# Altium schematic sheet REX 9628 Extern Kernel 8.SchDoc("REX 9628 Extern Kernel 8")
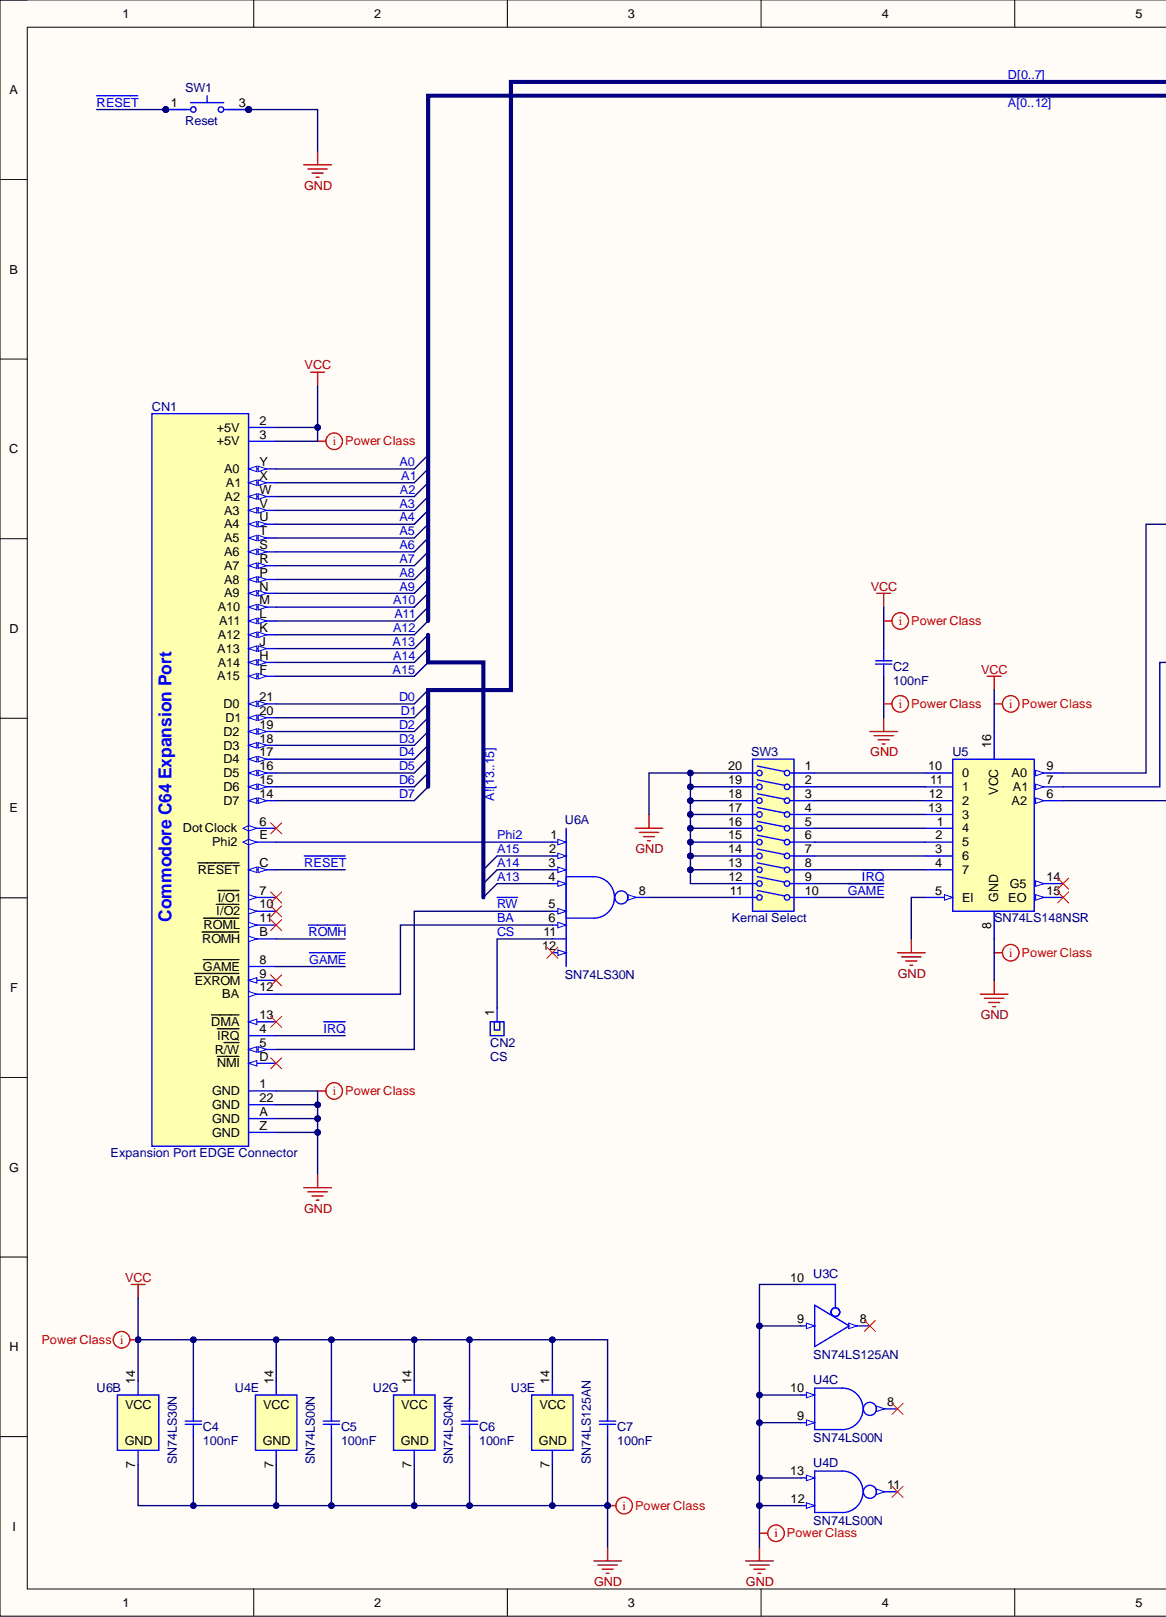
[diagram p0: REX 9628 Extern Kernel 8.SchDoc, left]
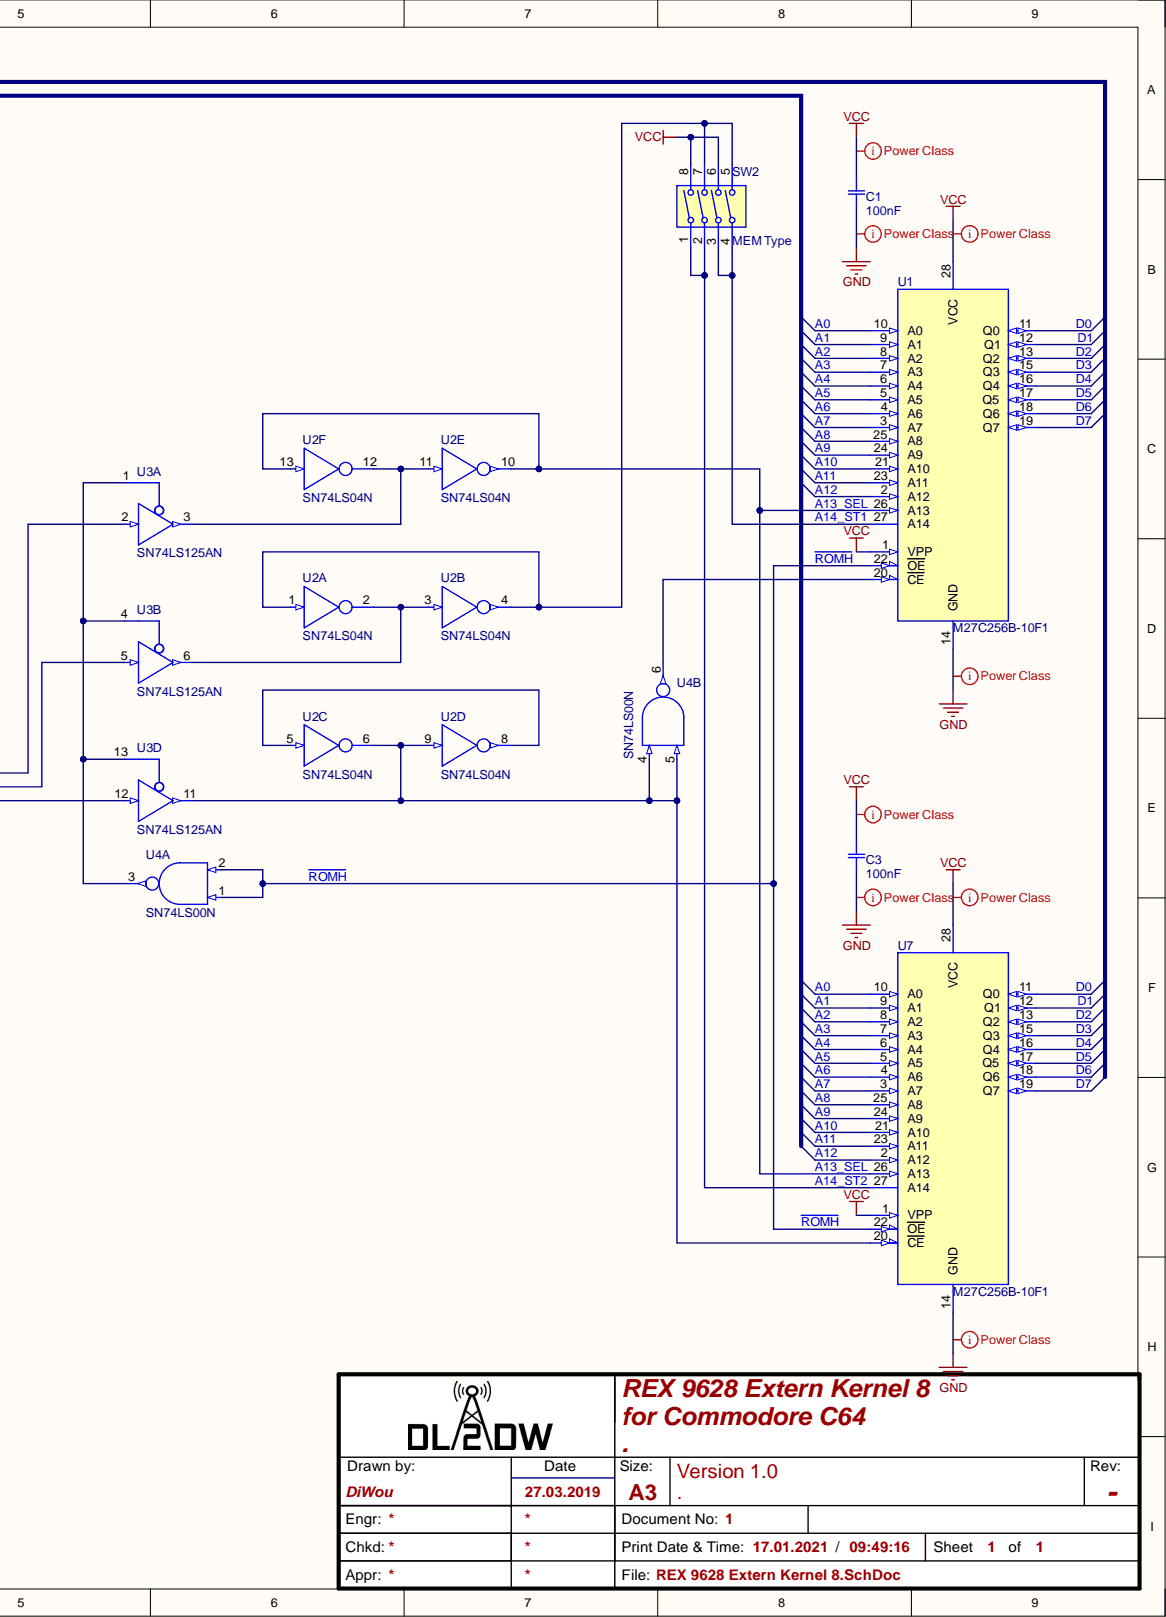
[diagram p1: REX 9628 Extern Kernel 8.SchDoc, right]

## Parts
C1  100nF  [CAP Disc 100nF P2.54mm D5.5mm T3mm]
C2  100nF  [CAP Disc 100nF P2.54mm D5.5mm T3mm]
C3  100nF  [CAP Disc 100nF P2.54mm D5.5mm T3mm]
C4  100nF  [CAP Disc 100nF P2.54mm D5.5mm T3mm]
C5  100nF  [CAP Disc 100nF P2.54mm D5.5mm T3mm]
C6  100nF  [CAP Disc 100nF P2.54mm D5.5mm T3mm]
C7  100nF  [CAP Disc 100nF P2.54mm D5.5mm T3mm]
CN1  Expansion Port EDGE Connector  [C64 Expansion Port EDGE Connector]
CN2  CS  [Pin Header 01x01 2.54mm Horizontal Male]
SW1  Reset  [Push Button 12x12mm H8.50mm THT]
SW2  MEM Type  [DIP Switch x04]
SW3  Kernal Select  [DIP Switch x10]
U1  M27C256B-10F1  [DIP-28 W15.24mm EPROM with Socket]
U2  SN74LS04N  [SN74LS04N with Socket]  (parts A,B,C,D,E,F,G)
U3  SN74LS125AN  [SN74LS125AN with Socket]  (parts A,B,C,D,E)
U4  SN74LS00N  [SN74LS00N]  (parts A,B,C,D,E)
U5  SN74LS148NSR  [SN74LS148N with Socket]
U6  SN74LS30N  [SN74LS30N with Socket]  (parts A,B)
U7  M27C256B-10F1  [DIP-28 W15.24mm EPROM with Socket]

## Nets
GND: C1.2 C2.2 C3.2 C4.2 C5.2 C6.2 C7.2 CN1.1(GND) CN1.22(GND) CN1.A(GND) CN1.Z(GND) SW1.3 SW1.4 SW3.12 SW3.13 SW3.14 SW3.15 SW3.16 SW3.17 SW3.18 SW3.19 SW3.20 U1.14(GND) U2.7 U3.7 U3.9 U3.10 U4.7 U4.9 U4.10 U4.12 U4.13 U5.5(EI) U5.8(GND) U6.7 U7.14(GND)
VCC: C1.1 C2.1 C3.1 C4.1 C5.1 C6.1 C7.1 CN1.2(+5V) CN1.3(+5V) SW2.6 SW2.8 U1.1(VPP) U1.28(VCC) U2.14 U3.14 U4.14 U5.16(VCC) U6.14 U7.1(VPP) U7.28(VCC)
~{GAME}: CN1.8(GAME) SW3.10
~{IRQ}: CN1.4(IRQ) SW3.9
~{RESET}: CN1.C(RESET) SW1.1 SW1.2
~{ROMH}: CN1.B(ROMH) U1.22(OE) U4.1 U4.2 U7.22(OE)
A0: CN1.Y(A0) U1.10(A0) U7.10(A0)  [also labelled A]
A1: CN1.X(A1) U1.9(A1) U7.9(A1)  [also labelled A]
A2: CN1.W(A2) U1.8(A2) U7.8(A2)  [also labelled A]
A3: CN1.V(A3) U1.7(A3) U7.7(A3)  [also labelled A]
A4: CN1.U(A4) U1.6(A4) U7.6(A4)  [also labelled A]
A5: CN1.T(A5) U1.5(A5) U7.5(A5)  [also labelled A]
A6: CN1.S(A6) U1.4(A6) U7.4(A6)  [also labelled A]
A7: CN1.R(A7) U1.3(A7) U7.3(A7)  [also labelled A]
A8: CN1.P(A8) U1.25(A8) U7.25(A8)  [also labelled A]
A9: CN1.N(A9) U1.24(A9) U7.24(A9)  [also labelled A]
A10: CN1.M(A10) U1.21(A10) U7.21(A10)  [also labelled A]
A11: CN1.L(A11) U1.23(A11) U7.23(A11)  [also labelled A]
A12: CN1.K(A12) U1.2(A12) U7.2(A12)  [also labelled A]
A13: CN1.J(A13) U6.4
A13_SEL: U1.26(A13) U2.10 U2.13 U7.26(A13)
A14: CN1.H(A14) U6.3
A14_ST1: SW2.3 SW2.4 U1.27(A14)
A14_ST2: SW2.1 SW2.2 U7.27(A14)
A15: CN1.F(A15) U6.2
BA: CN1.12(BA) U6.6
CS: CN2.1 U6.11
D0: CN1.21(D0) U1.11(Q0) U7.11(Q0)  [also labelled D]
D1: CN1.20(D1) U1.12(Q1) U7.12(Q1)  [also labelled D]
D2: CN1.19(D2) U1.13(Q2) U7.13(Q2)  [also labelled D]
D3: CN1.18(D3) U1.15(Q3) U7.15(Q3)  [also labelled D]
D4: CN1.17(D4) U1.16(Q4) U7.16(Q4)  [also labelled D]
D5: CN1.16(D5) U1.17(Q5) U7.17(Q5)  [also labelled D]
D6: CN1.15(D6) U1.18(Q6) U7.18(Q6)  [also labelled D]
D7: CN1.14(D7) U1.19(Q7) U7.19(Q7)  [also labelled D]
Phi2: CN1.E(Phi2) U6.1
~{RW}: CN1.5(R/W) U6.5
NetSW2_5: SW2.5 SW2.7 U2.1 U2.4
NetSW3_1: SW3.1 U5.10
NetSW3_2: SW3.2 U5.11
NetSW3_3: SW3.3 U5.12
NetSW3_4: SW3.4 U5.13
NetSW3_5: SW3.5 U5.1
NetSW3_6: SW3.6 U5.2
NetSW3_7: SW3.7 U5.3
NetSW3_8: SW3.8 U5.4
NetSW3_11: SW3.11 U6.8
NetU1_20: U1.20(CE) U4.6
NetU2_2: U2.2 U2.3 U3.6
NetU2_5: U2.5 U2.8
NetU2_6: U2.6 U2.9 U3.11 U4.4 U4.5 U7.20(CE)
NetU2_11: U2.11 U2.12 U3.3
NetU3_1: U3.1 U3.4 U3.13 U4.3
NetU3_2: U3.2 U5.9(A0)
NetU3_5: U3.5 U5.7(A1)
NetU3_12: U3.12 U5.6(A2)
A!13:  [also labelled A!]
A!14:  [also labelled A!]
A!15:  [also labelled A!]

Not connected: CN1 6(Dot_Clock) 7(I/O1) 9(EXROM) 10(I/O2) 11(ROML) 13(DMA) D(NMI); U3 8; U4 8 11; U5 14(G5) 15(EO); U6 12

## Library data of the parts
C1-C7 = CMP-Capacitors-Ceramic_Capacitors-00007-3: Ceramic Disc Capacitors 100nF -20%~+80% 50V Through Hole,P=2.54mm RoHS; Component Kind: Standard; ComponentLink1URL: https://lcsc.com/product-detail/Others_Dersonic-CC1H104ZA1PD3F5O3000_C353909.html; Library Name: PCB-Tech Cloud
CN1 = CMP-Commodore-00008-2: Expansion Port EDGE Connector for Interface Cards; Component Kind: Standard; Library Name: PCB-Tech Cloud
CN2 = CMP-Connectors-Male_PIN_Headers-00000-2: CONN HEADER .100 SNGL STR 1POS; Connector Type: Male Pin; Number of Positions: 1; Color: Black; Component Kind: Standard; Contact Mating Length: 0.318inch; Contact Plating: Gold; Library Name: PCB-Tech Cloud; Mount: Through Hole; Number of Rows: 1; Package Quantity: 1; Packaging: Bulk; Termination: Solder
SW1 = CMP-Switches-0011-3: Black Button Tactile Switch, NO 50 mA 5mm Through Hole; Actuator Material: Polymer,Liquid Crystal Polymer; Actuator Type: Standard; Contact Current Rating: 50mA; Illumination Type: Non-Illuminated,Illuminated; Mechanical Life: 500000Cycles; Operating Force: 160gf; Switch Function: Off-Mom; Switch Travel: 0.3mm; Throw Configuration: SPST; Actuator Length: 5mm; Component Kind: Standard; Contact Material: Stainless Steel,Silver; Library Name: PCB-Tech Cloud; Max Operating Temperature: 85°C; Min Operating Temperature: -40°C; Mount: Through Hole; Packaging: Bulk; REACH SVHC: No SVHC; Termination: PC Pin
SW2 = CMP-Switches-0003-2: SWITCH SLIDE DIP SPST 25MA 24V; Actuator Type: Slide (Standard); Body Material: Nylon,Polyamide; Electrical Life: 2000Cycles; Mechanical Life: 5000Cycles; Number of Positions: 4; Pitch: 0.1inch; Washable: No; Component Kind: Standard; Contact Plating: Gold; Current Rating: 25mA; Lead Free: Lead Free; Length: 0.439inch; Library Name: PCB-Tech Cloud; Max Operating Temperature: 85°C; Min Operating Temperature: -40°C; Mount: Through Hole; Package Quantity: 47; Packaging: Rail/Tube; Termination: PC Pin; Throw Configuration: SPST; Voltage Rating (DC): 24V
SW3 = CMP-Switches-0009-3: SWITCH SLIDE DIP SPST 25MA 24V; Actuator Type: Slide (Standard); Body Material: Nylon,Polyamide; Electrical Life: 2000Cycles; Mechanical Life: 5000Cycles; Number of Positions: 10; Pitch: 0.1inch; Washable: No; Component Kind: Standard; Contact Plating: Gold; Current Rating: 25mA; Length: 1.039inch; Library Name: PCB-Tech Cloud; Max Operating Temperature: 85°C; Min Operating Temperature: -40°C; Mount: Through Hole; Package Quantity: 20; Packaging: Rail/Tube; Termination: PC Pin; Throw Configuration: SPST; Voltage Rating (DC): 24V
U1 U7 = CMP-ICs-Memory-00005-3: IC EPROM 256K PARALLEL 28CDIP; Access Time: 100ns; Density: 256Kb; Frequency: 100GHz; Max Supply Voltage: 5.5V; Memory Type: EPROMs,EPROM; Min Supply Voltage: 4.5V; Nominal Supply Current: 50mA; Operating Supply Voltage: 5V; Case/Package: DIP; Component Kind: Standard; Height: 4.5mm; Interface: Parallel; Lead Free: Lead Free; Length: 37.34mm; Library Name: PCB-Tech Cloud; Max Operating Temperature: 70°C; Memory Size: 256Kb; Min Operating Temperature: 0°C; Mount: Through Hole; Number of Pins: 28; Package Quantity: 13; Packaging: Rail/Tube; Radiation Hardening: No; REACH SVHC: No SVHC; Width: 13.36mm
U2 = CMP-ICs-74xx-series-00006-2: IC HEX INVERTER 14-DIP; High Level Output Current: -400uA; Logic Function: Buffer,Inverter; Low Level Output Current: 8mA; Max Supply Voltage: 5.25V; Min Supply Voltage: 4.75V; Nominal Supply Current: 100uA; Number of Bits: 6; Number of Inputs: 1; Operating Supply Voltage: 5V; Propagation Delay: 15ns; Schmitt Trigger Input: No; Turn-On Delay Time: 22ns; Case/Package: PDIP; Component Kind: Standard; Contact Plating: Gold; Height: 4.57mm; Lead Free: Lead Free; Length: 19.3mm; Library Name: PCB-Tech Cloud; Max Operating Temperature: 70°C; Max Output Current: 8mA; Min Operating Temperature: 0°C; Mount: Through Hole; Number of Circuits: 6; Number of Elements: 6; Number of Gates: 6; Number of Output Lines: 6; Number of Pins: 14; Output Current: 8mA; Package Quantity: 25; Packaging: Rail/Tube; Radiation Hardening: No; REACH SVHC: No SVHC; Termination: Through Hole; Weight: 0.032734oz; Width: 6.35mm
U3 = CMP-ICs-74xx-series-00054-1: IC BUS BUFF TRI-ST QD 14DIP; High Level Output Current: -2.6mA; Logic Function: Buffer,Inverting; Low Level Output Current: 24mA; Max Output Current: 16mA; Max Supply Voltage: 5.25V; Min Supply Voltage: 4.75V; Nominal Supply Current: 32mA; Number of Bits: 4; Number of Bits per Element: 1; Operating Supply Voltage: 5V; Polarity: Non-Inverting; Propagation Delay: 18ns; Quiescent Current: 11mA; Schmitt Trigger Input: No; Case/Package: PDIP; Component Kind: Standard; Contact Plating: Gold; Height: 4.57mm; Lead Free: Lead Free; Length: 19.3mm; Library Name: PCB-Tech Cloud; Max Operating Temperature: 70°C; Min Operating Temperature: 0°C; Mount: Through Hole; Number of Channels: 4; Number of Circuits: 4; Number of Elements: 4; Number of Input Lines: 4; Number of Inputs: 4; Number of Output Lines: 4; Number of Outputs: 4; Number of Pins: 14; Output Current: 24mA; Package Quantity: 25; Packaging: Rail/Tube; REACH SVHC: No SVHC; Termination: Through Hole; Turn-On Delay Time: 18ns; Width: 6.35mm
U4 = CMP-ICs-74xx-series-00000-3: IC, 74LS, 74LS00, DIP14, 5.25V; High Level Output Current: -400uA; Logic Function: NAND; Low Level Output Current: 8mA; Min Supply Voltage: 4.75V; Number of Bits: 4; Number of Input Lines: 2; Number of Output Lines: 1; Operating Supply Voltage: 5V; Output Current: 16mA; Propagation Delay: 15ns; Quiescent Current: 2.4mA; Schmitt Trigger Input: No; Case/Package: PDIP; Component Kind: Standard; Contact Plating: Gold; Height: 4.57mm; Lead Free: Lead Free; Length: 19.3mm; Library Name: PCB-Tech Cloud; Max Operating Temperature: 70°C; Max Supply Voltage: 5.25V; Min Operating Temperature: 0°C; Mount: Through Hole; Number of Circuits: 4; Number of Elements: 4; Number of Gates: 4; Number of Inputs: 2; Number of Outputs: 1; Number of Pins: 14; Package Quantity: 25; Packaging: Rail/Tube; Radiation Hardening: No; REACH SVHC: No SVHC; Turn-On Delay Time: 15ns; Weight: 0.032734oz; Width: 6.35mm
U5 = CMP-ICs-74xx-series-00053-2: IC PRIORITY ENCODER 8-3L 16SO; Bandwidth: 35MHz; Independent Circuits: 1; Logic Function: Multiplexer; Max Supply Voltage: 5.25V; Min Supply Voltage: 4.75V; Number of Bits: 8; Number of Output Lines: 3; Operating Supply Voltage: 5V; Propagation Delay: 13ns; Supply Type: Single; Turn-On Delay Time: 55ns; Case/Package: SOP; Component Kind: Standard; Lead Free: Lead Free; Library Name: PCB-Tech Cloud; Max Operating Temperature: 70°C; Min Operating Temperature: 0°C; Mount: Surface Mount; Number of Channels: 1; Number of Input Lines: 8; Number of Inputs: 8; Number of Outputs: 3; Number of Pins: 16; Package Quantity: 2000; Packaging: Tape and Reel; Radiation Hardening: No; Weight: 0.007079oz
U6 = CMP-ICs-74xx-series-00029-2: IC GATE NAND 1CH 8-INP 14DIP; High Level Output Current: -16mA; Low Level Output Current: 400uA; Max Output Current: 8mA; Max Supply Voltage: 5.25V; Min Supply Voltage: 4.75V; Nominal Supply Current: 100uA; Number of Bits: 1; Number of Input Lines: 8; Operating Supply Voltage: 5V; Propagation Delay: 20ns; Turn-On Delay Time: 22ns; Case/Package: PDIP; Component Kind: Standard; Contact Plating: Gold; Lead Free: Lead Free; Library Name: PCB-Tech Cloud; Logic Function: NAND; Max Operating Temperature: 70°C; Min Operating Temperature: 0°C; Mount: Through Hole; Number of Circuits: 1; Number of Elements: 1; Number of Gates: 1; Number of Inputs: 8; Number of Output Lines: 1; Number of Outputs: 1; Number of Pins: 14; Output Current: 8mA; Package Quantity: 25; Packaging: Rail/Tube; Radiation Hardening: No; REACH SVHC: No SVHC; Termination: Through Hole; Weight: 0.032734oz
